# Altium schematic sheet sheet1_mcu.SchDoc
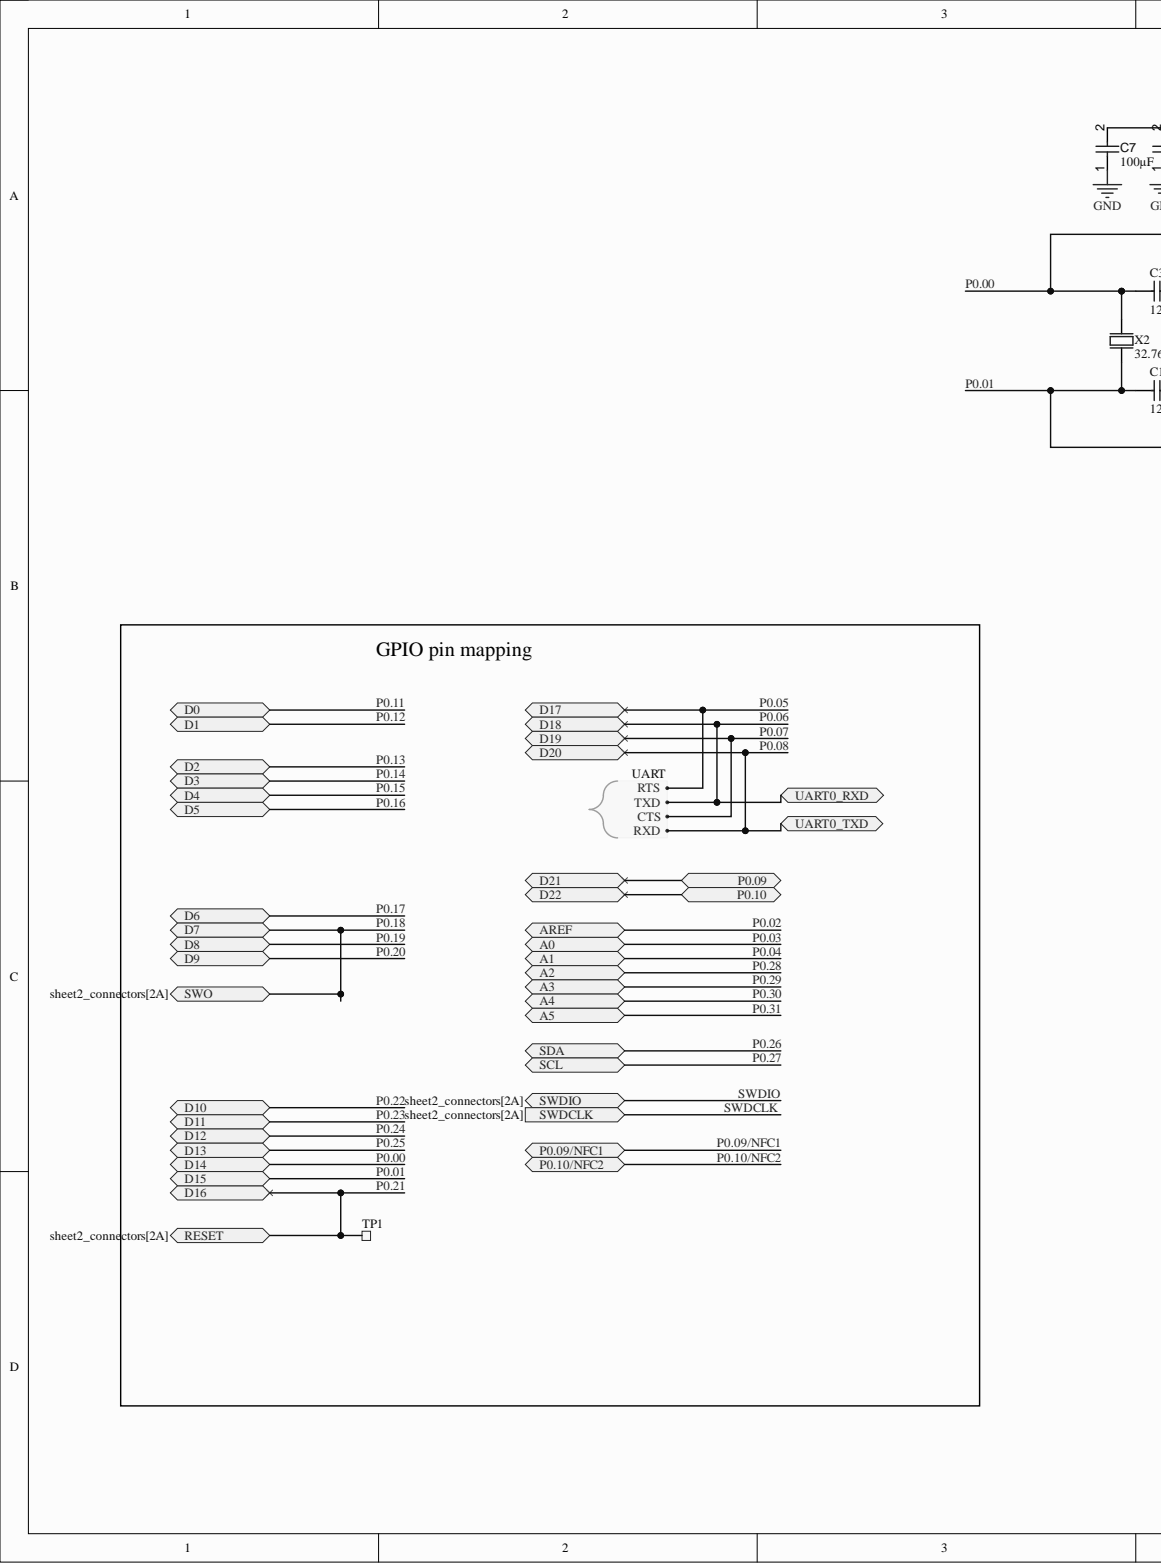
[diagram p0: sheet1_mcu.SchDoc, left]
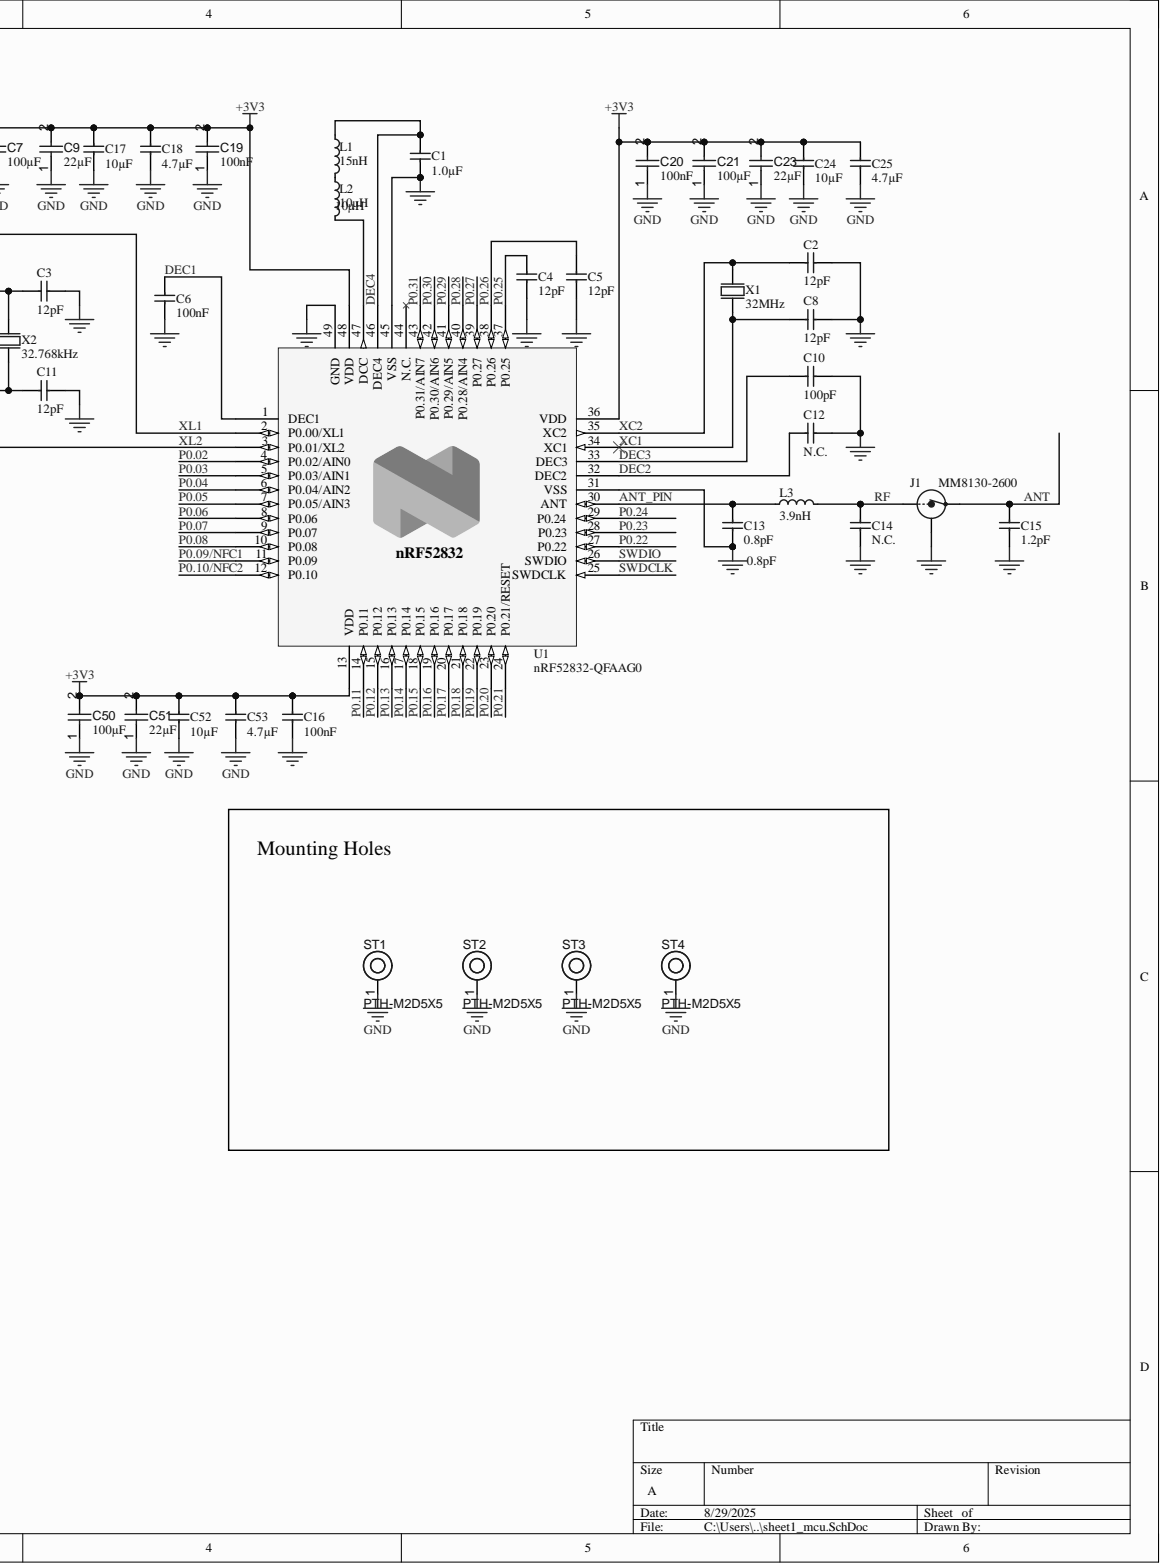
[diagram p1: sheet1_mcu.SchDoc, right]

## Parts
C1  1.0µF  [CAPC1608X06L]
C2  12pF  [CAPC1005X04L]
C3  12pF  [CAPC1005X04L]
C4  12pF  [CAPC1005X04L]
C5  12pF  [CAPC1005X04L]
C6  100nF  [CAPC1005X04L]
C7  value 100µF  [CAPC0805(2012)145_L]  (hidden comment: Capacitor 100µF +/-20% 6.3V 0805)
C8  12pF  [CAPC1005X04L]
C9  value 22µF  [CAPC0805(2012)145_L]  (hidden comment: Capacitor 22µF +/-40% 16V 0805)
C10  100pF  [CAPC1005X04L]
C11  12pF  [CAPC1005X04L]
C12  N.C.  [CAPC1005X04L_NC]
C13  0.8pF  [CAPC1005X04L]
C14  N.C.  [CAPC1005X04L_NC]
C15  1.2pF  [CAPC1005X04L]
C16  100nF  [CAPC1005X04L]
C17  10µF  [CAPC1608X06L]
C18  4.7µF  [CAPC1608X06L]
C19  value 100nF  [CAPC2013X95X50LL10T25]  (hidden comment: Capacitor 100 nF +/-10% 50 V 0805)
C20  value 100nF  [CAPC2013X95X50LL10T25]  (hidden comment: Capacitor 100 nF +/-10% 50 V 0805)
C21  value 100µF  [CAPC0805(2012)145_L]  (hidden comment: Capacitor 100µF +/-20% 6.3V 0805)
C23  value 22µF  [CAPC0805(2012)145_L]  (hidden comment: Capacitor 22µF +/-40% 16V 0805)
C24  10µF  [CAPC1608X06L]
C25  4.7µF  [CAPC1608X06L]
C50  value 100µF  [CAPC0805(2012)145_L]  (hidden comment: Capacitor 100µF +/-20% 6.3V 0805)
C51  value 22µF  [CAPC0805(2012)145_L]  (hidden comment: Capacitor 22µF +/-40% 16V 0805)
C52  10µF  [CAPC1608X06L]
C53  4.7µF  [CAPC1608X06L]
J1  MM8130-2600  [COAXIAL-SWF]
L1  15nH  [INDC1005X04L]
L2  10µH  [INDC1608X06L]
L3  3.9nH  [INDC1005X04L]
ST1  PTH-M2D5X5  [PTH-M2D5X5]
ST2  PTH-M2D5X5  [PTH-M2D5X5]
ST3  PTH-M2D5X5  [PTH-M2D5X5]
ST4  PTH-M2D5X5  [PTH-M2D5X5]
TP1  Ø1.0mm,SMD  [TP_SMD_D1.0mm]  (value not drawn)  (no BOM)
U1  nRF52832-QFAAG0  [QFN40P600X600X90-48N]
X1  32MHz  [BT-XTAL_2016]
X2  32.768kHz  [XTAL_3215]

## Ports
A0, A1, A2, A3, A4, A5, AREF, D0, D1, D2, D3, D4, D5, D6, D7, D8, D9, D10, D11, D12, D13, D14, D15, D16, D17, D18, D19, D20, D21, D22, P0.09, P0.09/NFC1, P0.10, P0.10/NFC2, RESET, SCL, SDA, SWDCLK, SWDIO, SWO, UART0_RXD, UART0_TXD

## Nets
+3V3: C7.2 C9.2 C16.1 C17.2 C18.2 C19.2 C20.2 C21.2 C23.2 C24.2 C25.2 C50.2 C51.2 C52.2 C53.2 U1.13(VDD) U1.36(VDD) U1.48(VDD)
GND: C1.1 C2.2 C3.1 C4.2 C5.2 C6.2 C7.1 C8.2 C9.1 C10.2 C11.1 C12.2 C13.1 C14.2 C15.2 C16.2 C17.1 C18.1 C19.1 C20.1 C21.1 C23.1 C24.1 C25.1 C50.1 C51.1 C52.1 C53.1 J1.2 ST1.1 ST2.1 ST3.1 ST4.1 U1.31(VSS) U1.45(VSS) U1.49(GND) X1.2 X1.4
ANT: C15.1 J1.3
ANT_PIN: C13.2 L3.1 U1.30(ANT)
DEC1: C6.1 U1.1(DEC1)
DEC2: C12.1 U1.32(DEC2)
DEC3: C10.1 U1.33(DEC3)
DEC4: C1.2 L1.1 U1.46(DEC4)
P0.00: C3.2 U1.2(P0.00/XL1) X2.1 ; port D14
P0.01: C11.2 U1.3(P0.01/XL2) X2.2 ; port D15
P0.02: U1.4(P0.02/AIN0) ; port AREF
P0.03: U1.5(P0.03/AIN1) ; port A0
P0.04: U1.6(P0.04/AIN2) ; port A1
P0.05: U1.7(P0.05/AIN3) ; port D17
P0.06: U1.8(P0.06) ; port D18, port UART0_RXD
P0.07: U1.9(P0.07) ; port D19
P0.08: U1.10(P0.08) ; port D20, port UART0_TXD
P0.09/NFC1: U1.11(P0.09) ; port P0.09/NFC1
P0.10/NFC2: U1.12(P0.10) ; port P0.10/NFC2
P0.11: U1.14(P0.11) ; port D0
P0.12: U1.15(P0.12) ; port D1
P0.13: U1.16(P0.13) ; port D2
P0.14: U1.17(P0.14) ; port D3
P0.15: U1.18(P0.15) ; port D4
P0.16: U1.19(P0.16) ; port D5
P0.17: U1.20(P0.17) ; port D6
P0.18: U1.21(P0.18) ; port D7, port SWO
P0.19: U1.22(P0.19) ; port D8
P0.20: U1.23(P0.20) ; port D9
P0.21: TP1.1 U1.24(P0.21/RESET) ; port D16, port RESET
P0.22: U1.27(P0.22) ; port D10
P0.23: U1.28(P0.23) ; port D11
P0.24: U1.29(P0.24) ; port D12
P0.25: C4.1 U1.37(P0.25) ; port D13
P0.26: C5.1 U1.38(P0.26) ; port SDA
P0.27: U1.39(P0.27) ; port SCL
P0.28: U1.40(P0.28/AIN4) ; port A2
P0.29: U1.41(P0.29/AIN5) ; port A3
P0.30: U1.42(P0.30/AIN6) ; port A4
P0.31: U1.43(P0.31/AIN7) ; port A5
RF: C14.1 J1.1 L3.2
SWDCLK: U1.25(SWDCLK) ; port SWDCLK
SWDIO: U1.26(SWDIO) ; port SWDIO
XC1: C8.1 U1.34(XC1) X1.1
XC2: C2.1 U1.35(XC2) X1.3
NetL1_2: L1.2 L2.1
NetL2_2: L2.2 U1.47(DCC)
D21: ; port D21, port P0.09
D22: ; port D22, port P0.10

Not connected: U1 44(N.C.)

## Library data of the parts
C1 = C0603-1µ0: Capacitor, X7R, ±10%; Manufacturer: N.A.; Part number IPS: 00000000616; Artikkelnr Noca: 20039282; Note: 16V; Pad Count: 2; Component Class: Capacitors; Component Kind: Standard; ComponentLink1Description: Datasheet; ComponentLink1URL: N.A.; ComponentLink2Description: Supplier link; ComponentLink2URL: N.A.; Footprint Doc: 0603; HelpURL: N.A.; LatestRevisionDate: 2013-06-05; Library Name: pca10040.IntLib; Manufacturer Part Number: N.A.; Part Number: C0603-1µ0; Part number Norautron: N.A.; PartId: 100200271; Published: 2009-05-08; Supplier 1: N.A.; Supplier 2: N.A.; Supplier Part Number 1: N.A.; Supplier Part Number 2: N.A.; Value: 1.0µF; Author: rsk
C2-C5 C8 C11 = C0402-12p: Capacitor, NP0, ±2%; Manufacturer: N.A.; Part number IPS: 00000002398; Artikkelnr Noca: 20029159; Note: 50V; Pad Count: 2; Component Class: Capacitors; Component Kind: Standard; ComponentLink1Description: Datasheet; ComponentLink1URL: N.A.; ComponentLink2Description: Supplier link; ComponentLink2URL: N.A.; Footprint Doc: 0402; HelpURL: N.A.; LatestRevisionDate: 2011-05-11; Library Name: pca10040.IntLib; Manufacturer Part Number: N.A.; Part Number: C0402-12p; Part number Norautron: N.A.; PartId: 100200137; Published: 2009-05-08; Supplier 1: N.A.; Supplier 2: N.A.; Supplier Part Number 1: N.A.; Supplier Part Number 2: N.A.; Value: 12pF; Author: rsk
C6 C16 = C0402-100n: Capacitor, X7R, ±10%; Manufacturer: N.A.; Part number IPS: 00000005296; Artikkelnr Noca: 20039200; Note: 16V; Pad Count: 2; Component Class: Capacitors; Component Kind: Standard; ComponentLink1Description: Datasheet; ComponentLink1URL: N.A.; ComponentLink2Description: Supplier link; ComponentLink2URL: N.A.; Footprint Doc: 0402; HelpURL: N.A.; LatestRevisionDate: 2009-11-23; Library Name: pca10040.IntLib; Manufacturer Part Number: N.A.; Part Number: C0402-100n; Part number Norautron: N.A.; PartId: 100200184; Published: 2009-05-08; Supplier 1: N.A.; Supplier 2: N.A.; Supplier Part Number 1: N.A.; Supplier Part Number 2: N.A.; Value: 100nF; Author: rsk
C7 C21 C50 = CMP-001-00054-4: Chip Capacitor, 100µF +/-20%, 6.3V, 0805, Thickness 1.45 mm; Tolerance: 10%; Case/Package: 0805; Component Kind: Standard; Max Operating Temperature: 85°C; Min Operating Temperature: -55°C; Mounting Technology: SMT; Pins: 2; Voltage Rating: 6.3V; Library Name: Greenhouse Lysimeter Project
C9 C23 C51 = CMP-001-00081-4: Chip Capacitor, 22µF +/-40%, 16V, 0805, Thickness 1.45 mm; Tolerance: 5%; Case/Package: 0805; Component Kind: Standard; Max Operating Temperature: 85°C; Min Operating Temperature: -55°C; Mounting Technology: SMT; Pins: 2; Voltage Rating: 16V; Library Name: Greenhouse Lysimeter Project
C10 = C0402-100p: Capacitor, NP0, ±5%; Manufacturer: N.A.; Part number IPS: 00000002361; Artikkelnr Noca: 20029135; Note: 50V; Pad Count: 2; Component Class: Capacitors; Component Kind: Standard; ComponentLink1Description: Datasheet; ComponentLink1URL: N.A.; ComponentLink2Description: Supplier link; ComponentLink2URL: N.A.; Footprint Doc: 0402; HelpURL: N.A.; LatestRevisionDate: 2009-05-08; Library Name: pca10040.IntLib; Manufacturer Part Number: N.A.; Part Number: C0402-100p; Part number Norautron: N.A.; PartId: 100200148; Published: 2009-05-08; Supplier 1: N.A.; Supplier 2: N.A.; Supplier Part Number 1: N.A.; Supplier Part Number 2: N.A.; Value: 100pF; Author: rsk
C12 C14 = C0402-N.C.: Not mounted; Artikkelnr Noca: N.A.; Assembly: Not mounted; Note: Chip Cap; Pad Count: 2; Component Class: Capacitors; Component Kind: Standard; ComponentLink1Description: Datasheet; ComponentLink1URL: N.A.; ComponentLink2Description: Supplier link; ComponentLink2URL: N.A.; Footprint Doc: 0402; HelpURL: N.A.; LatestRevisionDate: 2009-05-08; Library Name: pca10040.IntLib; Manufacturer: N.A.; Manufacturer Part Number: N.A.; Part Number: C0402-N.C.; Part number IPS: N.A.; Part number Norautron: N.A.; PartId: 100200105; Published: 2009-05-08; Supplier 1: N.A.; Supplier 2: N.A.; Supplier Part Number 1: N.A.; Supplier Part Number 2: N.A.; Value: N.C.; Author: rsk
C13 = C0402-0.8p: Capacitor, NP0, ±5%; Manufacturer: Murata; Manufacturer Part Number: GRM1555C1HR80WA01D; Part number IPS: 00000007782; Part number Norautron: N.A.; Artikkelnr Noca: 20029147; Note: 50V; Pad Count: 2; Component Class: Capacitors; Component Kind: Standard; ComponentLink1Description: Datasheet; ComponentLink1URL: https://www.murata.com/~/media/webrenewal/support/library/catalog/products/capacitor/mlcc/c02e.ashx?la=en-us; ComponentLink2Description: Supplier link; ComponentLink2URL: N.A.; Footprint Doc: 0402; HelpURL: N.A.; LatestRevisionDate: 2012-11-21; Library Name: pca10040.IntLib; Part Number: C0402-0.8p; PartId: 100200116; Published: 2009-05-08; Supplier 1: Digi-Key; Supplier 2: N.A.; Supplier Part Number 1: 490-6270-1-ND; Supplier Part Number 2: N.A.; Value: 0.8pF; Author: rsk
C15 = C0402-1.2p: Capacitor, NP0, ±0.1pF; Manufacturer: N.A.; Part number IPS: 00000005410; Artikkelnr Noca: 20029157; Note: 50V; Pad Count: 2; Component Class: Capacitors; Component Kind: Standard; ComponentLink1Description: Datasheet; ComponentLink1URL: N.A.; ComponentLink2Description: Supplier link; ComponentLink2URL: N.A.; Footprint Doc: 0402; HelpURL: N.A.; LatestRevisionDate: 2011-05-11; Library Name: pca10040.IntLib; Manufacturer Part Number: N.A.; Part Number: C0402-1.2p; Part number Norautron: N.A.; PartId: 100200119; Published: 2009-05-08; Supplier 1: N.A.; Supplier 2: N.A.; Supplier Part Number 1: N.A.; Supplier Part Number 2: N.A.; Value: 1.2pF; Author: rsk
C17 C24 C52 = C0603-10µ: Capacitor, X5R, ±20%; Manufacturer: N.A.; Part number IPS: 00000007785; Artikkelnr Noca: 20039234; Note: 6.3V; Pad Count: 2; Component Class: Capacitors; Component Kind: Standard; ComponentLink1Description: Datasheet; ComponentLink1URL: N.A.; ComponentLink2Description: Supplier link; ComponentLink2URL: N.A.; Footprint Doc: 0603; HelpURL: N.A.; LatestRevisionDate: 2011-01-17; Library Name: pca10040.IntLib; Manufacturer Part Number: N.A.; Part Number: C0603-10µ; Part number Norautron: N.A.; PartId: 100200275; Published: 2011-01-17; Supplier 1: N.A.; Supplier 2: N.A.; Supplier Part Number 1: N.A.; Supplier Part Number 2: N.A.; Value: 10µF; Author: rsk
C18 C25 C53 = C0603-4µ7-10V-X7S: Capacitor, X7S, ±10%, 10V; Manufacturer: N.A.; Part number IPS: 00000001779; Artikkelnr Noca: 20030013; Pad Count: 2; Component Class: Capacitors; Component Kind: Standard; ComponentLink1Description: Datasheet; ComponentLink1URL: N.A.; ComponentLink2Description: Supplier link; ComponentLink2URL: N.A.; Footprint Doc: 0603; HelpURL: N.A.; LatestRevisionDate: 2016-08-15; Library Name: pca10040.IntLib; Manufacturer Part Number: N.A.; Note: 10V; Part Number: C0603-4µ7-10V-X7S; Part number Norautron: N.A.; PartId: 100200351; Published: 2016-08-15; Supplier 1: Digi-Key; Supplier 2: N.A.; Supplier Part Number 1: 445-14258-1-ND; Supplier Part Number 2: N.A.; Value: 4.7µF; Author: rsk
C19 C20 = CMP-001-00018-6: Chip Capacitor, 100 nF, +/- 10%, 50 V, 0805 (2012 Metric); Capacitor Type: Ceramic; Voltage Rating: 50V; Case/Package: 0805; Component Kind: Standard; Max Operating Temperature: 125°C; Min Operating Temperature: -55°C; Mounting Technology: SM; Pins: 2; RoHS Compliant: True; Tolerance: 10%; Library Name: Greenhouse Lysimeter Project
J1 = MM8130-2600: Coaxial Connector with Switch; Manufacturer: Murata; Part number IPS: 00000009955; Artikkelnr Noca: 55029011; Note: N.A.; Pad Count: 3; Component Class: Connectors; Component Kind: Standard; ComponentLink1Description: Datasheet; ComponentLink1URL: N.A.; ComponentLink2Description: Supplier link; ComponentLink2URL: N.A.; Footprint Doc: COAXIAL-SWF; HelpURL: http://www.murata.com/products/catalog/pdf/o33e.pdf; LatestRevisionDate: 2014-04-24; Library Name: pca10040.IntLib; Manufacturer Part Number: MM8130-2600; Part Number: MM8130-2600; Part number Norautron: N.A.; PartId: 100500400; Published: 2014-04-24; Supplier 1: Digi-Key; Supplier 2: Mouser; Supplier Part Number 1: 490-4981-1-ND; Supplier Part Number 2: 81-MM8130-2600RA2; Value: MM8130-2600; Author: rsk
L1 = L0402-15n: Inductor, 320mA, ±5%; Manufacturer: Taiyo Yuden; Manufacturer Part Number: HK100515NJ-T; Part number IPS: 00000001262; Part number Norautron: N.A.; Artikkelnr Noca: 33029323; Note: High frequency chip inductor ±10%; Pad Count: 2; Component Class: Inductors; Component Kind: Standard; ComponentLink1Description: Datasheet; ComponentLink1URL: N.A.; ComponentLink2Description: Supplier link; ComponentLink2URL: N.A.; Footprint Doc: 0402; HelpURL: http://www.yuden.co.jp/productdata/catalog/en/mlci04_e.pdf; LatestRevisionDate: 2012-09-19; Library Name: pca10040.IntLib; Part Number: L0402-15n; PartId: 100300056; Published: 2010-07-12; Supplier 1: Digi-Key; Supplier 2: Farnell; Supplier Part Number 1: 587-1521-1-ND; Supplier Part Number 2: 658-1328; Value: 15nH; Author: rsk
L2 = L0603-10µ: Inductor, 80mA, ±10%; Manufacturer: Taiyo Yuden; Manufacturer Part Number: LBMF1608T100K; Part number IPS: 00000007794; Part number Norautron: N.A.; Artikkelnr Noca: 33029314; Note: LBMF1608T100K 10µH 80mA 0603; Pad Count: 2; Component Class: Inductors; Component Kind: Standard; ComponentLink1Description: Datasheet; ComponentLink1URL: N.A.; ComponentLink2Description: Supplier link; ComponentLink2URL: N.A.; Footprint Doc: 0603; HelpURL: http://www.yuden.co.jp/ut/product/pdf/elb2_e.pdf; LatestRevisionDate: 2012-09-19; Library Name: pca10040.IntLib; Part Number: L0603-10µ; PartId: 100300078; Published: 2010-02-24; Supplier 1: Digi-Key; Supplier 2: Farnell; Supplier Part Number 1: 587-1714-1-ND; Supplier Part Number 2: 178-6105; Value: 10µH; Author: rsk
L3 = L0402-3n9: Inductor, 170mA, ±0.1nH; Manufacturer: Murata; Manufacturer Part Number: LQP15MN3N9B02D; Part number IPS: 00000007793; Part number Norautron: N.A.; Artikkelnr Noca: 33029151; Note: High frequency chip inductor ±5%; Pad Count: 2; Component Class: Inductors; Component Kind: Standard; ComponentLink1Description: Datasheet; ComponentLink1URL: N.A.; ComponentLink2Description: Supplier link; ComponentLink2URL: N.A.; Footprint Doc: 0402; HelpURL: http://search.murata.co.jp/Ceramy/image/img/PDF/ENG/L0075S0084LQP15M.pdf; LatestRevisionDate: 2012-09-19; Library Name: pca10040.IntLib; Part Number: L0402-3n9; PartId: 100300049; Published: 2009-05-08; Supplier 1: Digi-Key; Supplier 2: N.A.; Supplier Part Number 1: 490-1131-1-ND; Supplier Part Number 2: N.A.; Value: 3.9nH; Author: rsk
ST1-ST4 = CMP-00028-00004-2: M2 PTH (2.5mm), 5mm pad; Component Kind: Standard; Pins: 1; Library Name: Greenhouse Lysimeter Project
TP1 = TP_SMD_Ø1.0MM: 1.0mm circular SMD testpad; Manufacturer: Nordic Semiconductor; Artikkelnr Noca: N.A.; Pad Count: 1; Component Class: Connectors; ComponentLink1Description: Datasheet; ComponentLink1URL: N.A.; ComponentLink2Description: Supplier link; ComponentLink2URL: N.A.; Footprint Doc: TP_SMD_Ø1.0mm; HelpURL: N.A.; LatestRevisionDate: 2021-03-05; Library Name: pca10040.IntLib; Manufacturer Part Number: N.A.; Note: N.A.; Part Number: TP_SMD_Ø1.0MM; Part number IPS: N.A.; Part number Norautron: N.A.; PartId: 100500475; Published: 2013-06-11; Supplier 1: N.A.; Supplier 2: N.A.; Supplier Part Number 1: N.A.; Supplier Part Number 2: N.A.; Value: Ø1.0mm,SMD; Author: rsk
U1 = nRF52832-QFAAG0: Multi-protocol Bluetooth Low Energy, ANT and 2.4GHz proprietary system-on-chip; Manufacturer: Nordic Semiconductor; Artikkelnr Noca: N.A.; Pad Count: 48; Component Class: nRF_Devices; Component Kind: Standard; ComponentLink1Description: Datasheet; ComponentLink1URL: N.A.; ComponentLink2Description: Supplier link; ComponentLink2URL: N.A.; Footprint Doc: QFN-48; HelpURL: N.A.; LatestRevisionDate: 2021-05-31; Library Name: pca10040.IntLib; Manufacturer Part Number: nRF52832-QFAAG0; Note: N.A.; Part Number: nRF52832-QFAAG0; Part number IPS: N.A.; Part number Norautron: N.A.; PartId: 102000228; Published: 2021-05-31; Supplier 1: Nordic Semiconductor; Supplier 2: N.A.; Supplier Part Number 1: nRF52832-QFAAG0; Supplier Part Number 2: N.A.; Value: nRF52832-QFAAG0; Author: rubr
X1 = XTAL_SMD2016_32MHz_8pF_Epson: XTAL SMD 2016, 32MHz, Cl=8pF, Tol: ±10ppm, Stab: ±10ppm; Manufacturer: Epson Toyocom; Manufacturer Part Number: Q22FA12800025; Part number IPS: N.A.; Artikkelnr Noca: 22019238; Note: XTAL SMD 32.000MHz; Pad Count: 4; Component Class: Oscillators; Component Kind: Standard; ComponentLink1Description: Datasheet; ComponentLink1URL: N.A.; ComponentLink2Description: Supplier link; ComponentLink2URL: N.A.; Footprint Doc: XTAL_2016; HelpURL: http://www.epsondevice.com/docs/qd/en/DownloadServlet?id=ID000449; LatestRevisionDate: 2014-11-27; Library Name: pca10040.IntLib; Part Number: XTAL_SMD2016_32MHz_8pF_Epson; Part number Norautron: N.A.; PartId: 101600036; Published: 2012-03-09; Supplier 1: Farnell; Supplier 2: N.A.; Supplier Part Number 1: 171-2806; Supplier Part Number 2: N.A.; Value: 32MHz; Author: rsk
X2 = XTAL_SMD3215_32.768kHz_9pF_Epson: XTAL SMD 3215, 32.768kHz, 9pF, ±20ppm; Manufacturer: Epson Toyocom; Manufacturer Part Number: FC-135 32.768KHZ ±20PPM,9.0PF; Part number IPS: N.A.; Artikkelnr Noca: 22019091; Note: XTAL SMD 32.768kHz; Pad Count: 2; Component Class: Oscillators; Component Kind: Standard; ComponentLink1Description: Datasheet; ComponentLink1URL: N.A.; ComponentLink2Description: Supplier link; ComponentLink2URL: N.A.; Footprint Doc: XTAL_3215; HelpURL: http://www.farnell.com/datasheets/57480.pdf; LatestRevisionDate: 2017-10-18; Library Name: pca10040.IntLib; Part Number: XTAL_SMD3215_32.768kHz_9pF_Epson; Part number Norautron: N.A.; PartId: 101600021; Published: 2010-10-18; Supplier 1: Digi-Key; Supplier 2: Farnell; Supplier Part Number 1: FC-135 32.7680KA-AC-ND; Supplier Part Number 2: 127-8037; Value: 32.768kHz; Author: rsk
